# Altium schematic sheet IRIS-FE-01-B.SchDoc
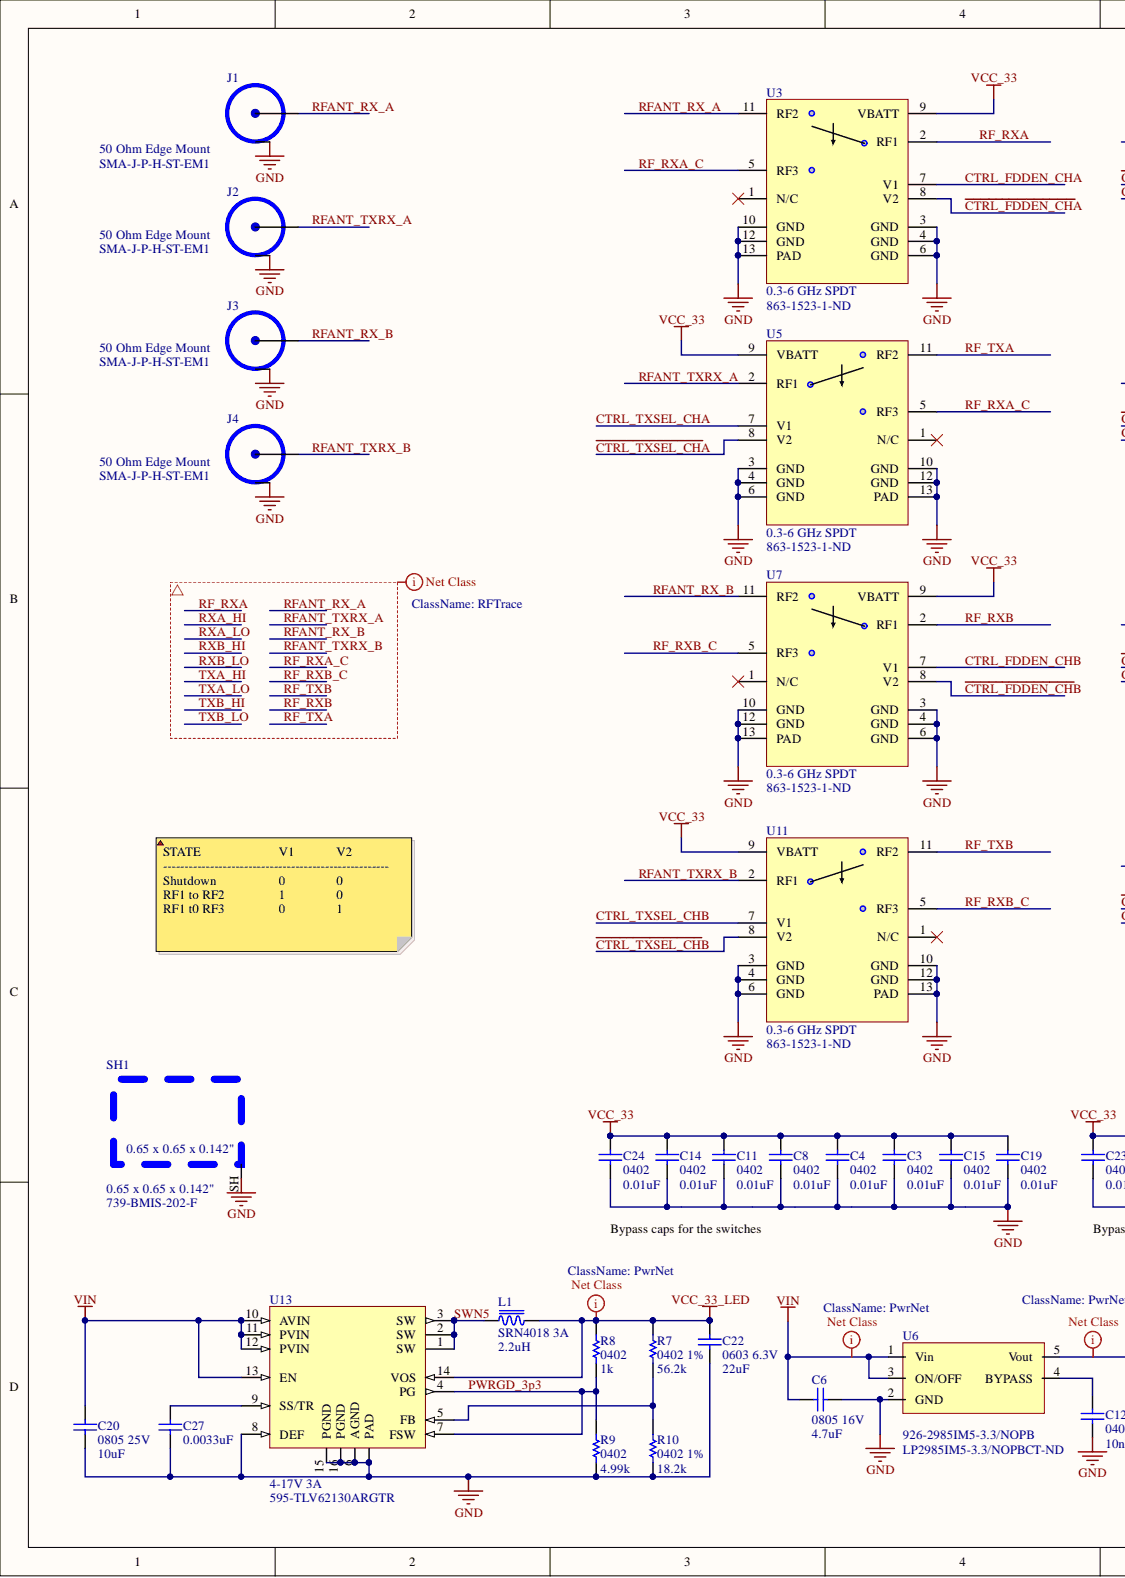
[diagram p0: IRIS-FE-01-B.SchDoc, left]
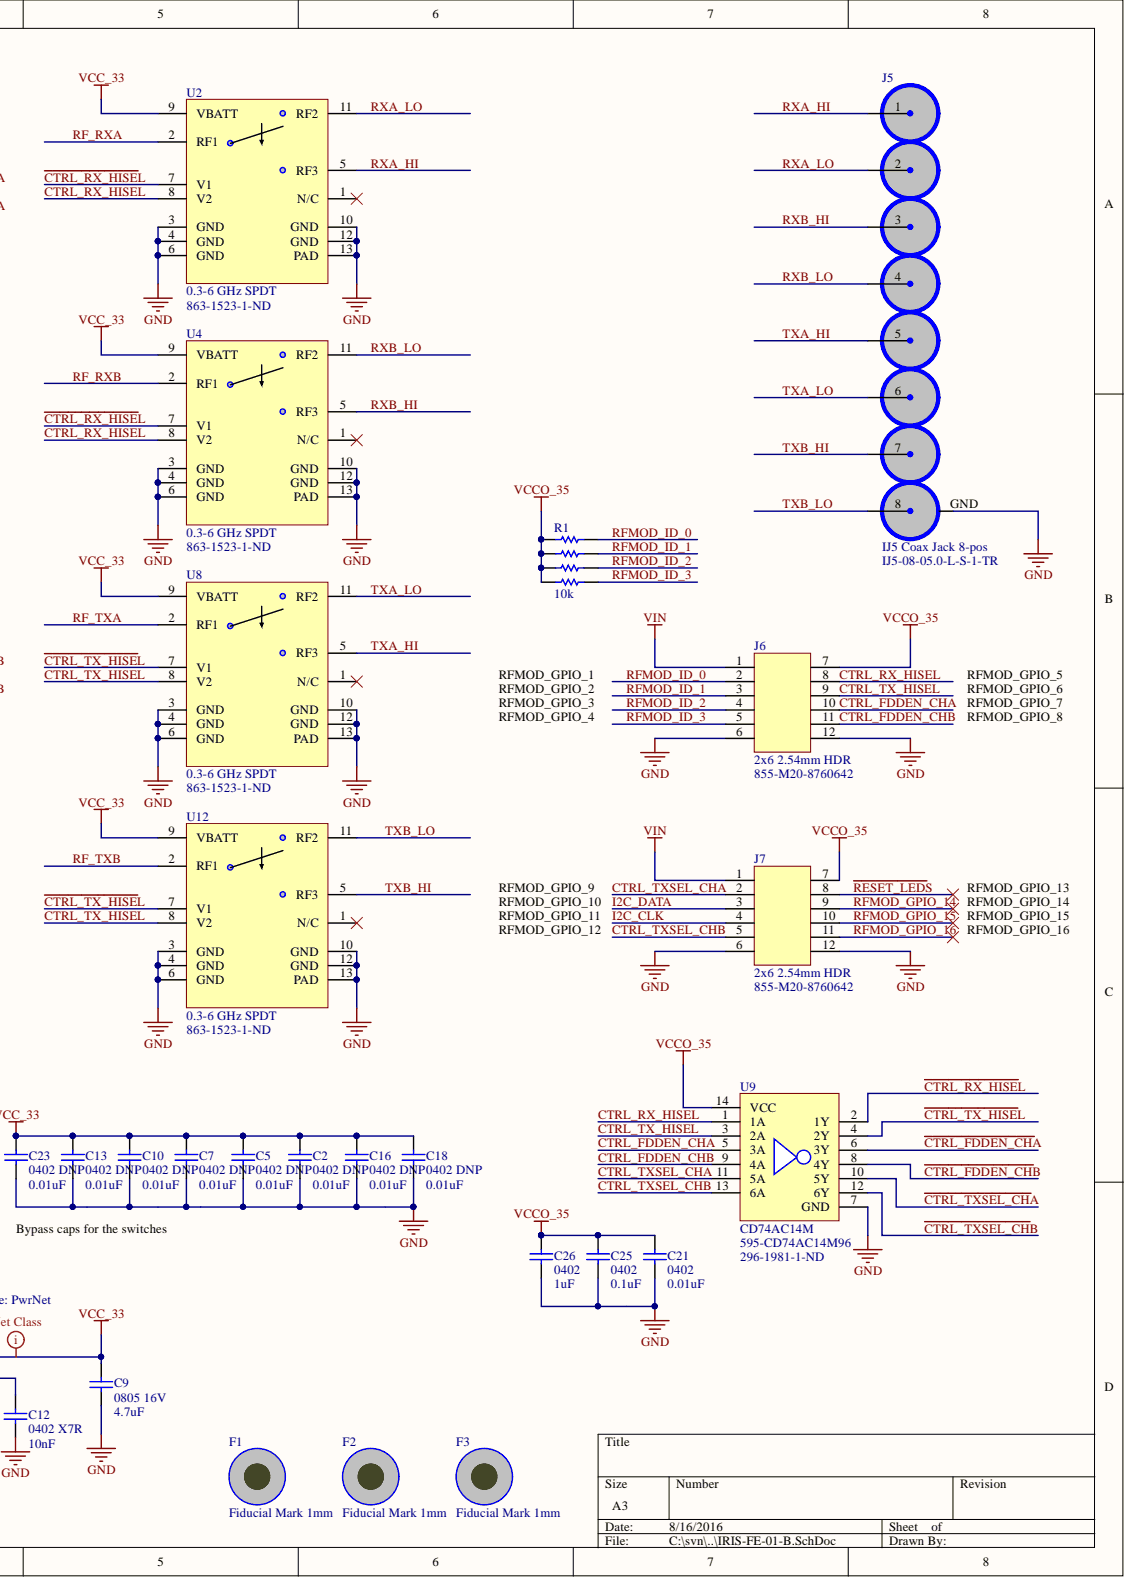
[diagram p1: IRIS-FE-01-B.SchDoc, right]

## Parts
C2  0402 DNP  value 0.01uF  [0402_SMT_CAP]
C3  0402  value 0.01uF  [0402_SMT_CAP]
C4  0402  value 0.01uF  [0402_SMT_CAP]
C5  0402 DNP  value 0.01uF  [0402_SMT_CAP]
C6  0805 16V  value 4.7uF  [0805_SMT_CAP]
C7  0402 DNP  value 0.01uF  [0402_SMT_CAP]
C8  0402  value 0.01uF  [0402_SMT_CAP]
C9  0805 16V  value 4.7uF  [0805_SMT_CAP]
C10  0402 DNP  value 0.01uF  [0402_SMT_CAP]
C11  0402  value 0.01uF  [0402_SMT_CAP]
C12  0402 X7R  value 10nF  [0402_SMT_CAP]
C13  0402 DNP  value 0.01uF  [0402_SMT_CAP]
C14  0402  value 0.01uF  [0402_SMT_CAP]
C15  0402  value 0.01uF  [0402_SMT_CAP]
C16  0402 DNP  value 0.01uF  [0402_SMT_CAP]
C18  0402 DNP  value 0.01uF  [0402_SMT_CAP]
C19  0402  value 0.01uF  [0402_SMT_CAP]
C20  0805 25V  value 10uF  [0805_SMT_CAP]
C21  0402  value 0.01uF  [0402_SMT_CAP]
C22  0603 6.3V  value 22uF  [0603_SMT_CAP]
C23  0402 DNP  value 0.01uF  [0402_SMT_CAP]
C24  0402  value 0.01uF  [0402_SMT_CAP]
C25  0402  value 0.1uF  [0402_SMT_CAP]
C26  0402  value 1uF  [0402_SMT_CAP]
C27  value 0.0033uF  [0402_SMT_CAP]  (hidden comment: 0402)
F1  Fiducial Mark 1mm  [1mm_FIDUCIAL]
F2  Fiducial Mark 1mm  [1mm_FIDUCIAL]
F3  Fiducial Mark 1mm  [1mm_FIDUCIAL]
J1  50 Ohm Edge Mount  Manufacturer Part Number 1: SMA-J-P-H-ST-EM1  [SMA-J-P-X-ST-EM1]
J2  50 Ohm Edge Mount  Manufacturer Part Number 1: SMA-J-P-H-ST-EM1  [SMA-J-P-X-ST-EM1]
J3  50 Ohm Edge Mount  Manufacturer Part Number 1: SMA-J-P-H-ST-EM1  [SMA-J-P-X-ST-EM1]
J4  50 Ohm Edge Mount  Manufacturer Part Number 1: SMA-J-P-H-ST-EM1  [SMA-J-P-X-ST-EM1]
J5  IJ5 Coax Jack 8-pos  [IJ5-08-05.0-L-S-1-TR]
J6  2x6 2.54mm HDR  Supplier Part Number 1: 855-M20-8760642  [M20-876-350012]
J7  2x6 2.54mm HDR  Supplier Part Number 1: 855-M20-8760642  [M20-876-350012]
L1  SRN4018 3A  value 2.2uH  [srn4018]
R1  value 10k  [EXB28V]  (hidden comment: 0402 Array)
R7  0402 1%  value 56.2k  [0402_SMT_RES]
R8  0402  value 1k  [0402_SMT_RES]
R9  0402  value 4.99k  [0402_SMT_RES]
R10  0402 1%  value 18.2k  [0402_SMT_RES]
SH1  0.65 x 0.65 x 0.142"  Supplier Part Number 1: 739-BMIS-202-F  [BMIS-202]
U2  0.3-6 GHz SPDT  Supplier Part Number 1: 863-1523-1-ND  [SKY13374-397LF-QFN12]
U3  0.3-6 GHz SPDT  Supplier Part Number 1: 863-1523-1-ND  [SKY13374-397LF-QFN12]
U4  0.3-6 GHz SPDT  Supplier Part Number 1: 863-1523-1-ND  [SKY13374-397LF-QFN12]
U5  0.3-6 GHz SPDT  Supplier Part Number 1: 863-1523-1-ND  [SKY13374-397LF-QFN12]
U6  LP2985IM5-3.3  Supplier Part Number 1: 926-2985IM5-3.3/NOPB  [DBV_SOT-23-5]
U7  0.3-6 GHz SPDT  Supplier Part Number 1: 863-1523-1-ND  [SKY13374-397LF-QFN12]
U8  0.3-6 GHz SPDT  Supplier Part Number 1: 863-1523-1-ND  [SKY13374-397LF-QFN12]
U9  CD74AC14M  Supplier Part Number 1: 595-CD74AC14M96  Supplier Part Number 2: 296-1981-1-ND  [TI-SOIC127P600X175-14N]
U11  0.3-6 GHz SPDT  Supplier Part Number 1: 863-1523-1-ND  [SKY13374-397LF-QFN12]
U12  0.3-6 GHz SPDT  Supplier Part Number 1: 863-1523-1-ND  [SKY13374-397LF-QFN12]
U13  4-17V 3A  Supplier Part Number 1: 595-TLV62130ARGTR  [S-PVQFN-N16]

## Nets
GND: C2.2 C3.2 C4.2 C5.2 C6.2 C7.2 C8.2 C9.2 C10.2 C11.2 C12.1 C13.2 C14.2 C15.2 C16.2 C18.2 C19.2 C20.1 C21.2 C22.2 C23.2 C24.2 C25.1 C26.2 C27.1 J1.2 J2.2 J3.2 J4.2 J5.GND J6.6 J6.12 J7.6 J7.12 R9.1 R10.1 SH1.SH U2.3(GND) U2.4(GND) U2.6(GND) U2.10(GND) U2.12(GND) U2.13(PAD) U3.3(GND) U3.4(GND) U3.6(GND) U3.10(GND) U3.12(GND) U3.13(PAD) U4.3(GND) U4.4(GND) U4.6(GND) U4.10(GND) U4.12(GND) U4.13(PAD) U5.3(GND) U5.4(GND) U5.6(GND) U5.10(GND) U5.12(GND) U5.13(PAD) U6.2(GND) U7.3(GND) U7.4(GND) U7.6(GND) U7.10(GND) U7.12(GND) U7.13(PAD) U8.3(GND) U8.4(GND) U8.6(GND) U8.10(GND) U8.12(GND) U8.13(PAD) U9.7(GND) U11.3(GND) U11.4(GND) U11.6(GND) U11.10(GND) U11.12(GND) U11.13(PAD) U12.3(GND) U12.4(GND) U12.6(GND) U12.10(GND) U12.12(GND) U12.13(PAD) U13.6(AGND) U13.8(DEF) U13.15(PGND) U13.16(PGND) U13.17(PAD)
VCC_33: C2.1 C3.1 C4.1 C5.1 C7.1 C8.1 C9.1 C10.1 C11.1 C13.1 C14.1 C15.1 C16.1 C18.1 C19.1 C23.1 C24.1 U2.9(VBATT) U3.9(VBATT) U4.9(VBATT) U5.9(VBATT) U6.5(Vout) U7.9(VBATT) U8.9(VBATT) U11.9(VBATT) U12.9(VBATT)
VCC_33_LED: C22.1 L1.2 R7.2 R8.2 U13.14(VOS)
VCCO_35: C21.1 C25.2 C26.1 J6.7 J7.7 R1.1 R1.2 R1.3 R1.4 U9.14(VCC)
VIN: C6.1 C20.2 J6.1 J7.1 U6.1(Vin) U6.3(ON/OFF) U13.10(AVIN) U13.11(PVIN) U13.12(PVIN) U13.13(EN)
~{CTRL_FDDEN_CHA}: U3.8(V2) U9.6(3Y)
~{CTRL_FDDEN_CHB}: U7.8(V2) U9.8(4Y)
~{CTRL_RX_HISEL}: U2.7(V1) U4.7(V1) U9.2(1Y)
~{CTRL_TX_HISEL}: U8.7(V1) U9.4(2Y) U12.7(V1)
~{CTRL_TXSEL_CHA}: U5.8(V2) U9.10(5Y)
~{CTRL_TXSEL_CHB}: U9.12(6Y) U11.8(V2)
~{RESET_LEDS}: J7.8
CTRL_FDDEN_CHA: J6.10 U3.7(V1) U9.5(3A)
CTRL_FDDEN_CHB: J6.11 U7.7(V1) U9.9(4A)
CTRL_RX_HISEL: J6.8 U2.8(V2) U4.8(V2) U9.1(1A)
CTRL_TX_HISEL: J6.9 U8.8(V2) U9.3(2A) U12.8(V2)
CTRL_TXSEL_CHA: J7.2 U5.7(V1) U9.11(5A)
CTRL_TXSEL_CHB: J7.5 U9.13(6A) U11.7(V1)
I2C_CLK: J7.4
I2C_DATA: J7.3
PWRGD_3P3: R8.1 R9.2 U13.4(PG) U13.7(FSW)
RF_RXA: U2.2(RF1) U3.2(RF1)
RF_RXA_C: U3.5(RF3) U5.5(RF3)
RF_RXB: U4.2(RF1) U7.2(RF1)
RF_RXB_C: U7.5(RF3) U11.5(RF3)
RF_TXA: U5.11(RF2) U8.2(RF1)
RF_TXB: U11.11(RF2) U12.2(RF1)
RFANT_RX_A: J1.1 U3.11(RF2)
RFANT_RX_B: J3.1 U7.11(RF2)
RFANT_TXRX_A: J2.1 U5.2(RF1)
RFANT_TXRX_B: J4.1 U11.2(RF1)
RFMOD_GPIO_14: J7.9
RFMOD_GPIO_15: J7.10
RFMOD_GPIO_16: J7.11
RFMOD_ID_0: J6.2 R1.8
RFMOD_ID_1: J6.3 R1.7
RFMOD_ID_2: J6.4 R1.6
RFMOD_ID_3: J6.5 R1.5
RXA_HI: J5.1 U2.5(RF3)
RXA_LO: J5.2 U2.11(RF2)
RXB_HI: J5.3 U4.5(RF3)
RXB_LO: J5.4 U4.11(RF2)
SWN5: L1.1 U13.1(SW) U13.2(SW) U13.3(SW)
TXA_HI: J5.5 U8.5(RF3)
TXA_LO: J5.6 U8.11(RF2)
TXB_HI: J5.7 U12.5(RF3)
TXB_LO: J5.8 U12.11(RF2)
NetC12_2: C12.2 U6.4(BYPASS)
NetC27_2: C27.2 U13.9(SS/TR)
NetR7_1: R7.1 R10.2 U13.5(FB)
N00017:

Not connected: U2 1(N/C); U3 1(N/C); U4 1(N/C); U5 1(N/C); U7 1(N/C); U8 1(N/C); U11 1(N/C); U12 1(N/C)

## Library data of the parts
C2-C5 C7 C8 C10 C11 C13-C16 C18 C19 C21 C23-C27 = Cap_0402: 0402 SMT Capacitor; Component Kind: Standard; Supplier 1: Mouser; Library Name: RNG_Parts.SchLib
  C2-C5 C7 C8 C10 C11 C13-C16 C18 C19 C21 C23 C24: Supplier Part Number 1: 81-GRM155R71H103KA8D
  C25: Supplier Part Number 1: 81-GRM155R71C104JA8D
  C26: Supplier Part Number 1: 81-GRM155R61E105KA2D
  C27: Supplier Part Number 1: 81-GRM155R71H332KA1J
C6 C9 C20 = Cap_0805: 0805 SMT Capacitor; Component Kind: Standard; Supplier 1: Mouser; Library Name: RNG_Parts.SchLib
  C6 C9: Supplier Part Number 1: 81-GRJ21BR71C475KE1L
  C20: Supplier Part Number 1: 81-GRM219R61E106KA2D
C12 = Cap Semi: Capacitor (Semiconductor SIM Model); Component Kind: Standard; LatestRevisionDate: 29-May-2009; LatestRevisionNote: IPC-7351 Footprint Added.; Library Name: Miscellaneous Devices.IntLib; PackageDescription: Chip Capacitor; PackageReference: C1206; Published: 8-Jun-2000; Supplier 1: Mouser; Supplier Part Number 1: 81-GCM155R71H103KA5D; Publisher: Altium Limited
C22 = Cap_0603: 0603 SMT Capacitor; Component Kind: Standard; Supplier 1: Mouser; Supplier Part Number 1: 81-GRM188R60J226ME0D; Library Name: RNG_Parts.SchLib
F1-F3 = Fiducial-1mm: Component Kind: Standard; Description: Fiducial Mark 1mm; Library Name: RNG_Parts.SchLib
J1-J4 = SMA-J-P-X-ST-EM1: Samtec SMA Edge Mount 50 Ohm; Component Kind: Standard; Manufacturer 1: Samtec; Supplier 1: Samtec; Supplier Part Number 1: SMA-J-P-H-ST-EM1; Library Name: Skylark_Master.SchLib
J5 = IJ5-08-05.0-X-S-1_1: Samtec 50 Ohm High Isolation RF Jack, 4-pos; Component Kind: Standard; Manufacturer 1: Samtec; Manufacturer Part Number 1: IJ5-08-05.0-L-S-1-TR; Supplier 1: Samtec; Supplier Part Number 1: IJ5-08-05.0-L-S-1-TR; Library Name: Skylark_Master.SchLib
J6 J7 = M20-8760642: Harwin 2.54mm Thru Straight Recepticle; Component Kind: Standard; Supplier 1: Mouser; Library Name: VUUM_Master.SchLib
L1 = SRN4018: SRN4018 Semi-Shielded SMT Power Inductor; Component Kind: Standard; Supplier 1: Mouser; Supplier Part Number 1: 652-SRN4018-2R2M; Library Name: RNG_Parts.SchLib
R1 = Res_0402_4xArray: 0402 SMT Resistor Array; Component Kind: Standard; Supplier 1: Mouser; Supplier 2: Digi-Key; Supplier Part Number 1: 667-EXB-28V103JX; Supplier Part Number 2: Y7103CT-ND; Library Name: Skylark_Master.SchLib
R7-R10 = Res_0402: 0402 SMT Resistor; Component Kind: Standard; Supplier 1: Mouser; Library Name: RNG_Parts.SchLib
  R7: Supplier Part Number 1: 667-ERJ-2RKF5622X
  R8: Supplier Part Number 1: 667-ERJ-2RKF1001X
  R9: Supplier Part Number 1: 667-ERJ-2RKF4991X
  R10: Supplier Part Number 1: 667-ERJ-2RKF1822X
SH1 = BMI-S-202-F: EMI Shield 0.65 x 0.65 x 0.142" LairdTech 2-pc; Component Kind: Standard; Supplier 1: Mouser; Library Name: Skylark_Master.SchLib
U2-U5 U7 U8 U11 U12 = SKY13374-397LF: 300 kHz to 6.0 GHz SPDT Switch 2x2mm QFN; Component Kind: Standard; Supplier 1: Digi-Key; Library Name: Skylark_Master.SchLib
U6 = LP2985IM5-3.3: TO LDO 3.3V 150mA Regulator w/ ON/OFF; Component Kind: Standard; Supplier 1: Mouser; Supplier 2: Digi-Key; Supplier Part Number 2: LP2985IM5-3.3/NOPBCT-ND; Library Name: Skylark_Master.SchLib
U9 = CD74AC14M: TI Hex Inverter in SOIC; Component Kind: Standard; Supplier 1: Mouser; Supplier 2: Digi-Key; Library Name: Skylark_Master.SchLib
U13 = TLV62130: 4-17V 3A Step-Down Voltage Converter; Component Kind: Standard; Supplier 1: Mouser; Library Name: RNG_Parts.SchLib
